# Altium schematic sheet MCU.SchDoc(MCU)
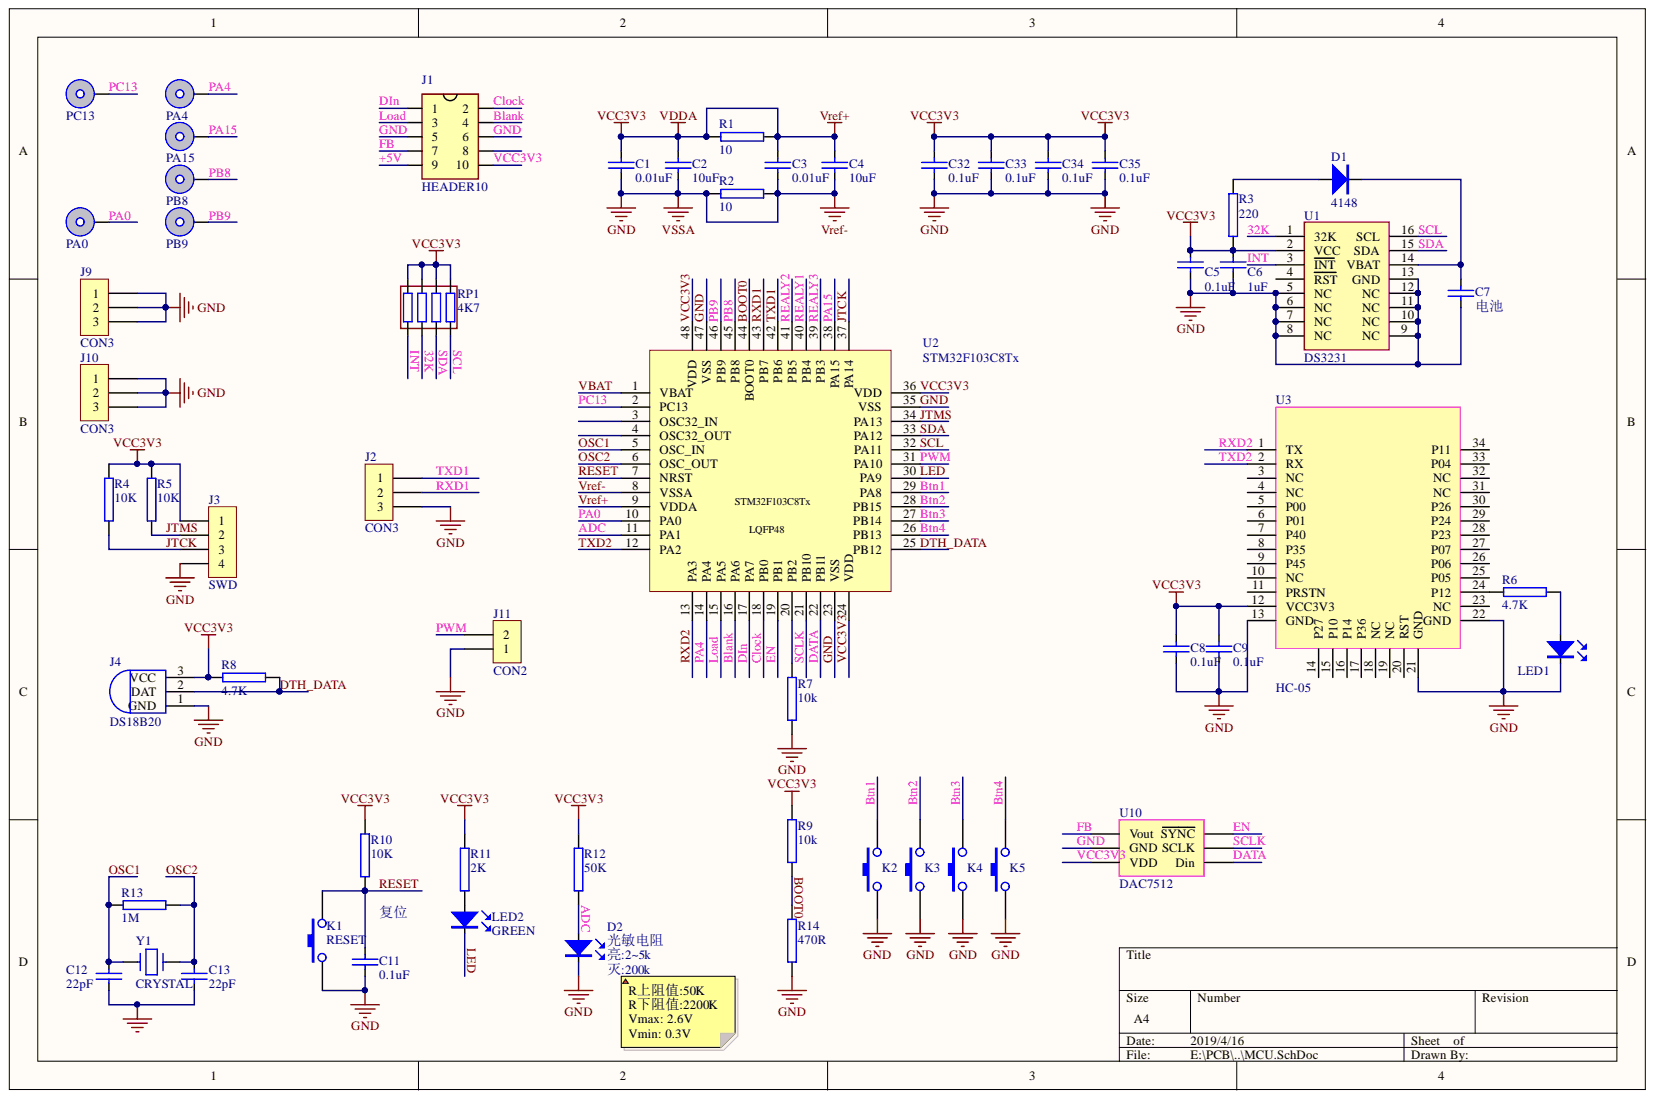
[diagram p0: MCU.SchDoc]

## Parts
C1  0.01uF  [C0603]
C2  10uF  [C0603]
C3  0.01uF  [C0603]
C4  10uF  [C0603]
C5  0.1uF  [C0603]
C6  1uF  [C0603]
C7  电池  [CR1220]
C8  0.1uF  [C0603]
C9  0.1uF  [C0603]
C11  0.1uF  [C0603]
C12  22pF  [C0603]
C13  22pF  [C0603]
C32  0.1uF  [C0603]
C33  0.1uF  [C0603]
C34  0.1uF  [C0603]
C35  0.1uF  [C0603]
D1  4148  [D1206]
D2  光敏电阻  [LED4]
J1  HEADER10  [HEAD100-10]
J2  CON3  [SIP-3]
J3  SWD  [SIP-4]
J4  DS18B20  [DS18B20]
J9  CON3  [SIP-3]
J10  CON3  [SIP-3]
J11  CON2  [SIP-2]
K1  RESET  [BUTTON-3*6*2.5]
K2  BUTTON  [BUTTON-3*6*2.5]  (value not drawn)
K3  BUTTON  [BUTTON-3*6*2.5]  (value not drawn)
K4  BUTTON  [BUTTON-3*6*2.5]  (value not drawn)
K5  BUTTON  [BUTTON-3*6*2.5]  (value not drawn)
LED1  LED  [LED0603]
LED2  GREEN  [LED0603]
PA0  TESTPAD  [TP-2]  (value not drawn)
PA4  TESTPAD  [TP-2]  (value not drawn)
PA15  TESTPAD  [TP-2]  (value not drawn)
PB8  TESTPAD  [TP-2]  (value not drawn)
PB9  TESTPAD  [TP-2]  (value not drawn)
PC13  TESTPAD  [TP-2]  (value not drawn)
R1  10  [R0603]
R2  10  [R0603]
R3  220  [R0603]
R4  10K  [R0603]
R5  10K  [R0603]
R6  4.7K  [R0603]
R7  10k  [R0603]
R8  4.7K  [R0603]
R9  10k  [R0603]
R10  10K  [R0603]
R11  2K  [R0603]
R12  50K  [R0603]
R13  1M  [R0603]
R14  470R  [R0603]
RP1  4K7  [R8P0603]
U1  DS3231  [S0-16]
U2  STM32F103C8Tx  [LQFP-48]
U3  HC-05  [HC-05]
U10  DAC7512  [SOT23-6]
Y1  CRYSTAL  [CRY-XTAL]

## Nets
32K: RP1.6 U1.1(32K)
+5V: J1.9
ADC: D2.1 R12.1 U2.11(PA1)
BLANK: J1.4 U2.16(PA6)
BOOT0: R9.1 R14.1 U2.44(BOOT0)
BTN1: K2.2 U2.29(PA8)
BTN2: K3.2 U2.28(PB15)
BTN3: K4.2 U2.27(PB14)
BTN4: K5.2 U2.26(PB13)
CLOCK: J1.2 U2.18(PB0)
DATA: U2.22(PB11) U10.4(Din)
DIN: J1.1 U2.17(PA7)
DTH_DATA: J4.2 R8.1 U2.25(PB12)
EN: U2.19(PB1) U10.6(SYNC)
FB: J1.7 U10.1(Vout)
GND: C1.1 C2.1 C3.1 C4.1 C5.1 C6.1 C7.2 C8.1 C9.1 C11.1 C12.2 C13.2 C32.1 C33.1 C34.1 C35.1 D2.2 J1.5 J1.6 J2.3 J3.4 J4.1 J9.1 J9.2 J9.3 J10.1 J10.2 J10.3 J11.1 K1.1 K2.1 K3.1 K4.1 K5.1 LED1.2 R2.1 R2.2 R7.1 R14.2 U1.5(NC) U1.6(NC) U1.7(NC) U1.8(NC) U1.9(NC) U1.10(NC) U1.11(NC) U1.12(NC) U1.13(GND) U2.8(VSSA) U2.23(VSS) U2.35(VSS) U2.47(VSS) U3.13(GND) U3.21(GND) U3.22(GND) U10.2(GND)
INT: RP1.8 U1.3(INT)
JTCK: J3.3 R4.1 U2.37(PA14)
JTMS: J3.2 R5.1 U2.34(PA13)
LED: LED2.2 U2.30(PA9)
LOAD: J1.3 U2.15(PA5)
OSC1: C12.1 R13.1 U2.5(OSC_IN) Y1.1
OSC2: C13.1 R13.2 U2.6(OSC_OUT) Y1.2
PA0: PA0.1 U2.10(PA0)
PA4: PA4.1 U2.14(PA4)
PA15: PA15.1 U2.38(PA15)
PB8: PB8.1 U2.45(PB8)
PB9: PB9.1 U2.46(PB9)
PC13: PC13.1 U2.2(PC13)
PWM: J11.2 U2.31(PA10)
REALY1: U2.40(PB4)
REALY2: U2.41(PB5)
REALY3: U2.39(PB3)
RESET: C11.2 K1.2 R10.1 U2.7(NRST)
RXD1: J2.2 U2.43(PB7)
RXD2: U2.13(PA3) U3.1(TX)
SCL: RP1.2 U1.16(SCL) U2.32(PA11)
SCLK: U2.21(PB10) U10.5(SCLK)
SDA: RP1.4 U1.15(SDA) U2.33(PA12)
TXD1: J2.1 U2.42(PB6)
TXD2: U2.12(PA2) U3.2(RX)
VBAT: U2.1(VBAT)
VCC3V3: C1.2 C2.2 C3.2 C4.2 C5.2 C6.2 C8.2 C9.2 C32.2 C33.2 C34.2 C35.2 J1.10 J3.1 J4.3 R1.1 R1.2 R3.2 R4.2 R5.2 R8.2 R9.2 R10.2 R11.2 R12.2 RP1.1 RP1.3 RP1.5 RP1.7 U1.2(VCC) U2.9(VDDA) U2.24(VDD) U2.36(VDD) U2.48(VDD) U3.12(VCC3V3) U10.3(VDD)
NetC7_1: C7.1 D1.2 U1.14(VBAT)
NetD1_1: D1.1 R3.1
NetLED1_1: LED1.1 R6.2
NetLED2_1: LED2.1 R11.1
NetR6_1: R6.1 U3.24(P12)
NetR7_2: R7.2 U2.20(PB2)

Not connected: J1 8; U1 4(RST); U2 3(OSC32_IN) 4(OSC32_OUT); U3 3(NC) 4(NC) 5(P00) 6(P01) 7(P40) 8(P35) 9(P45) 10(NC) 11(PRSTN) 14(P27) 15(P10) 16(P14) 17(P36) 18(NC) 19(NC) 20(RST) 23(NC) 25(P05) 26(P06) 27(P07) 28(P23) 29(P24) 30(P26) 31(NC) 32(NC) 33(P04) 34(P11)

## Library data of the parts
C1-C9 C11 C32-C35 = CAP: Component Kind: Standard; Library Name: MySchlib.SchLib
C12 C13 = CAP1: Component Kind: Standard
D1 = DIODE: Component Kind: Standard; Library Name: MySchlib.SchLib
D2 LED2 = LED: Component Kind: Standard
  D2: : 亮:2~5k; : 灭:200k; Library Name: MySchlib.SchLib
J3 = CON4: Component Kind: Standard; Library Name: MySchlib.SchLib
K1 = BUTTON: Component Kind: Standard; Library Name: MySchlib.SchLib
R1 R2 R4 R5 R7-R14 = RES: Component Kind: Standard
  R1 R2 R4 R5 R7-R10 R13 R14: Library Name: MySchlib.SchLib
R3 R6 = RES2: Component Kind: Standard
RP1 = RESX4: Component Kind: Standard; Library Name: MySchlib.SchLib
U1 = DS3131: Component Kind: Standard; Library Name: MySchlib.SchLib
J1 = HEADER10: Component Kind: Standard; Library Name: MySchlib.SchLib
J2 J9 J10 = CON3: Component Kind: Standard; Library Name: MySchlib.SchLib
J4 = DS18B20: Component Kind: Standard; Library Name: MySchlib.SchLib
J11 = CON2: Component Kind: Standard; Library Name: MySchlib.SchLib
K2-K5 = BUTTON: Component Kind: Standard; Library Name: MySchlib.SchLib
LED1 = LED: Component Kind: Standard; Library Name: MySchlib.SchLib
PA0 PA4 PA15 PB8 PB9 PC13 = TESTPAD: Component Kind: Standard; Library Name: MySchlib.SchLib
U2 = STM32F103C8Tx: Component Kind: Standard; Library Name: MySchlib.SchLib
U3 = HC-05: Component Kind: Standard; Library Name: MySchlib.SchLib
U10 = DAC7512: Component Kind: Standard; Library Name: PCB_Project.SCHLIB
Y1 = CRYSTAL: Component Kind: Standard; Library Name: MySchlib.SchLib
